# Altium schematic sheet WM_Ultrasonic.SchDoc
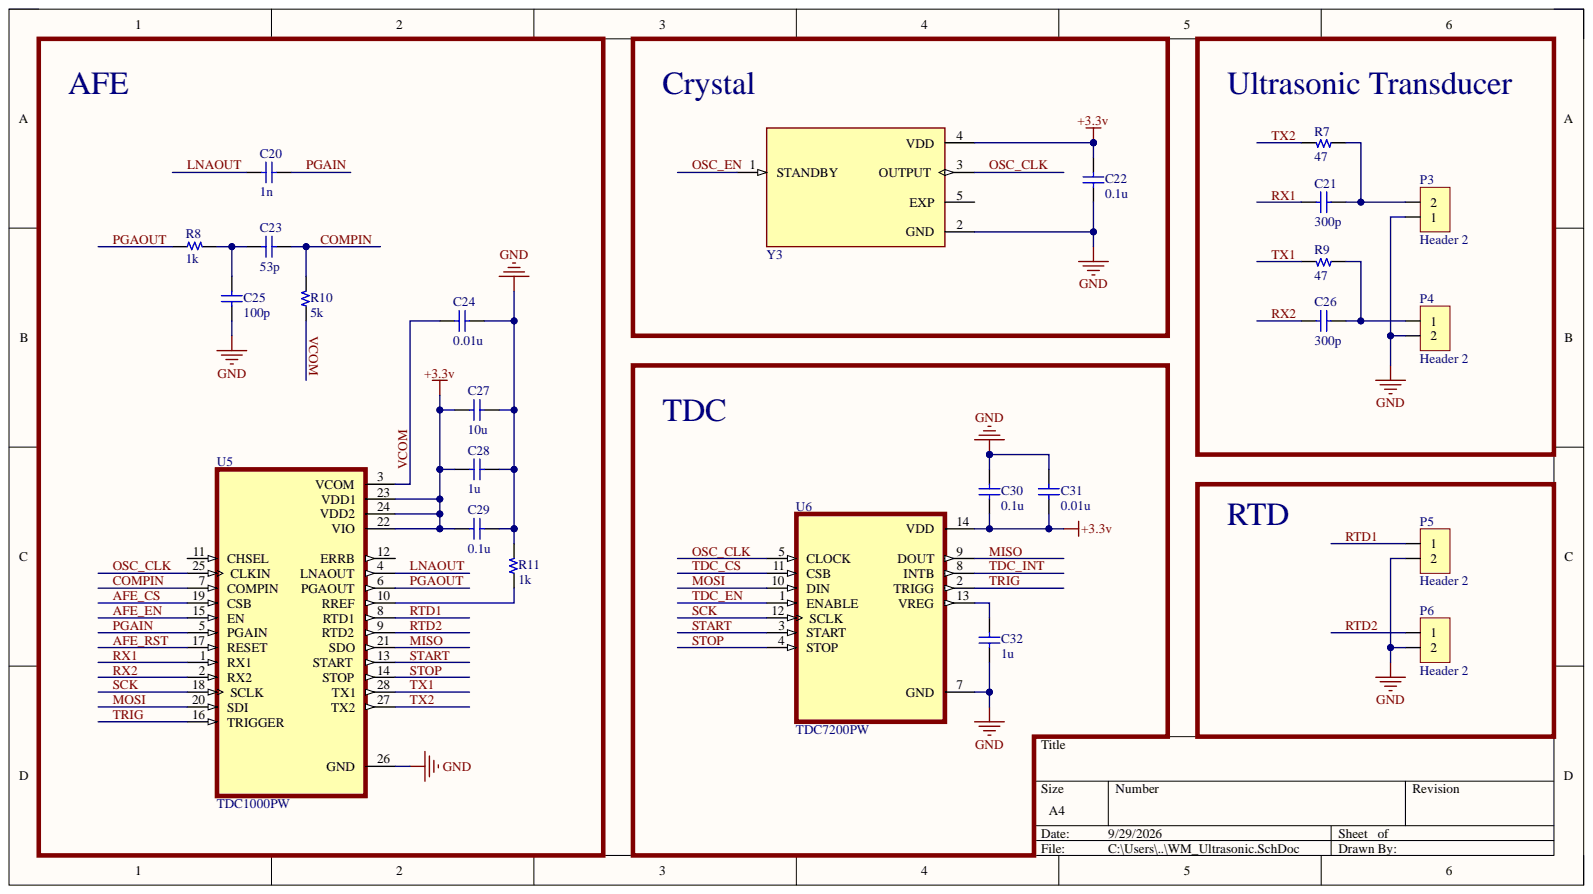
[diagram p0: WM_Ultrasonic.SchDoc]

## Parts
C20  1n  [C 0603]
C21  300p  [C 0603]
C22  0.1u  [C 0603]
C23  53p  [C 0603]
C24  0.01u  [C 0603]
C25  100p  [C 0603]
C26  300p  [C 0603]
C27  10u  [C 0603]
C28  1u  [C 0603]
C29  0.1u  [C 0603]
C30  0.1u  [C 0603]
C31  0.01u  [C 0603]
C32  1u  [C 0603]
P3  Header 2  [HDR/Header/2/BOX]
P4  Header 2  [HDR/Header/2/BOX]
P5  Header 2  [HDR/Header/2/BOX]
P6  Header 2  [HDR/Header/2/BOX]
R7  47  [R 0603]
R8  1k  [R 0603]
R9  47  [R 0603]
R10  5k  [R 0603]
R11  1k  [R 0603]
U5  TDC1000PW  [SOP65P640X120-28N]
U6  TDC7200PW  [SOP65P640X120-14N]
Y3  8MHz  [XTAL_ASVMB-8.000MHZ-XY-T]  (value not drawn)

## Nets
+3.3v: C22.1 C27.1 C28.1 C29.1 C30.1 C31.1 U5.22(VIO) U5.23(VDD1) U5.24(VDD2) U6.14(VDD) Y3.4(VDD)
GND: C22.2 C24.2 C25.1 C27.2 C28.2 C29.2 C30.2 C31.2 C32.1 P3.1 P4.2 P5.2 P6.2 R11.2 U5.26(GND) U6.7(GND) Y3.2(GND)
AFE_CS: U5.19(CSB)
AFE_EN: U5.15(EN)
AFE_RST: U5.17(RESET)
COMPIN: C23.2 R10.2 U5.7(COMPIN)
LNAOUT: C20.1 U5.4(LNAOUT)
MISO: U5.21(SDO) U6.9(DOUT)
MOSI: U5.20(SDI) U6.10(DIN)
OSC_CLK: U5.25(CLKIN) U6.5(CLOCK) Y3.3(OUTPUT)
OSC_EN: Y3.1(STANDBY)
PGAIN: C20.2 U5.5(PGAIN)
PGAOUT: R8.1 U5.6(PGAOUT)
RTD1: P5.1 U5.8(RTD1)
RTD2: P6.1 U5.9(RTD2)
RX1: C21.1 U5.1(RX1)
RX2: C26.1 U5.2(RX2)
SCK: U5.18(SCLK) U6.12(SCLK)
START: U5.13(START) U6.3(START)
STOP: U5.14(STOP) U6.4(STOP)
TDC_CS: U6.11(CSB)
TDC_EN: U6.1(ENABLE)
TDC_INT: U6.8(INTB)
TRIG: U5.16(TRIGGER) U6.2(TRIGG)
TX1: R9.2 U5.28(TX1)
TX2: R7.2 U5.27(TX2)
VCOM: C24.1 R10.1 U5.3(VCOM)
NetC21_2: C21.2 P3.2 R7.1
NetC23_1: C23.1 C25.2 R8.2
NetC26_2: C26.2 P4.1 R9.1
NetC32_2: C32.2 U6.13(VREG)
NetR11_1: R11.1 U5.10(RREF)

Not connected: U5 11(CHSEL) 12(ERRB); Y3 5(EXP)

## Library data of the parts
C20 C23-C25 C31 = Capacitor NP: Ceramic capacitor C0G/NP0; Component Kind: Standard; Library Name: chipfc_altium_libs.IntLib
C21 C22 C26 = Capacitor NP: Ceramic capacitor; Component Kind: Standard; Library Name: chipfc_altium_libs.IntLib
C27-C30 C32 = Capacitor NP: Ceramic capacitor X7R/X5R; Component Kind: Standard; Library Name: chipfc_altium_libs.IntLib
P3-P6 = Header 2: Header, 2-Pin; Component Kind: Standard; Library Name: chipfc_altium_libs.IntLib
R7 R9 = Resistor: Component Kind: Standard; Description: Resistor; Library Name: chipfc_altium_libs.IntLib
R8 R10 R11 = Resistor: Resistor 1%; Component Kind: Standard; Library Name: chipfc_altium_libs.IntLib
U5 = TDC1000PW: Ultrasonic sensing analog front end for level andconcentration sensing 28-TSSOP -40 to 125; MF: Texas Instruments; Availability: Warning; Component Kind: Standard; DigiKey-Purchase-URL: https://snapeda.com/shop?store=DigiKey&id=328084; Library Name: TDC1000PW.IntLib; Mouser-Purchase-URL: https://snapeda.com/shop?store=Mouser&id=328084; MP: TDC1000PW; Package: TSSOP-28 Texas Instruments; Price: None; SnapEDA_Link: https://www.snapeda.com/parts/TDC1000PW/Texas%20Instruments/view-part/328084/?ref=snap; Texas_Instruments-Purchase-URL: https://snapeda.com/shop?store=Texas+Instruments&id=328084
U6 = TDC7200PW: Time-to-digital converter for time-of-flight (ToF) applications for LIDAR and ultrasonic; MF: Texas Instruments; Check_prices: https://www.snapeda.com/parts/TDC7200PW/Texas+Instruments/view-part/?ref=eda; Component Kind: Standard; Library Name: TDC7200PW.IntLib; MP: TDC7200PW; Package: TSSOP-14 Texas Instruments; SnapEDA_Link: https://www.snapeda.com/parts/TDC7200PW/Texas+Instruments/view-part/?ref=snap
Y3 = ASVMB-8.000MHZ-XY-T: Oscillator 7050 8MHz; Availability: Unavailable; Component Kind: Standard; Library Name: ASVMB-8.000MHZ-XY-T.IntLib; MP: ASVMB-8.000MHZ-XY-T; Package: None; Price: None
